# Altium schematic sheet [8]-GSM_MODEM_CONNECTORS.SchDoc([8]-GSM_MODEM_CONNECTORS)
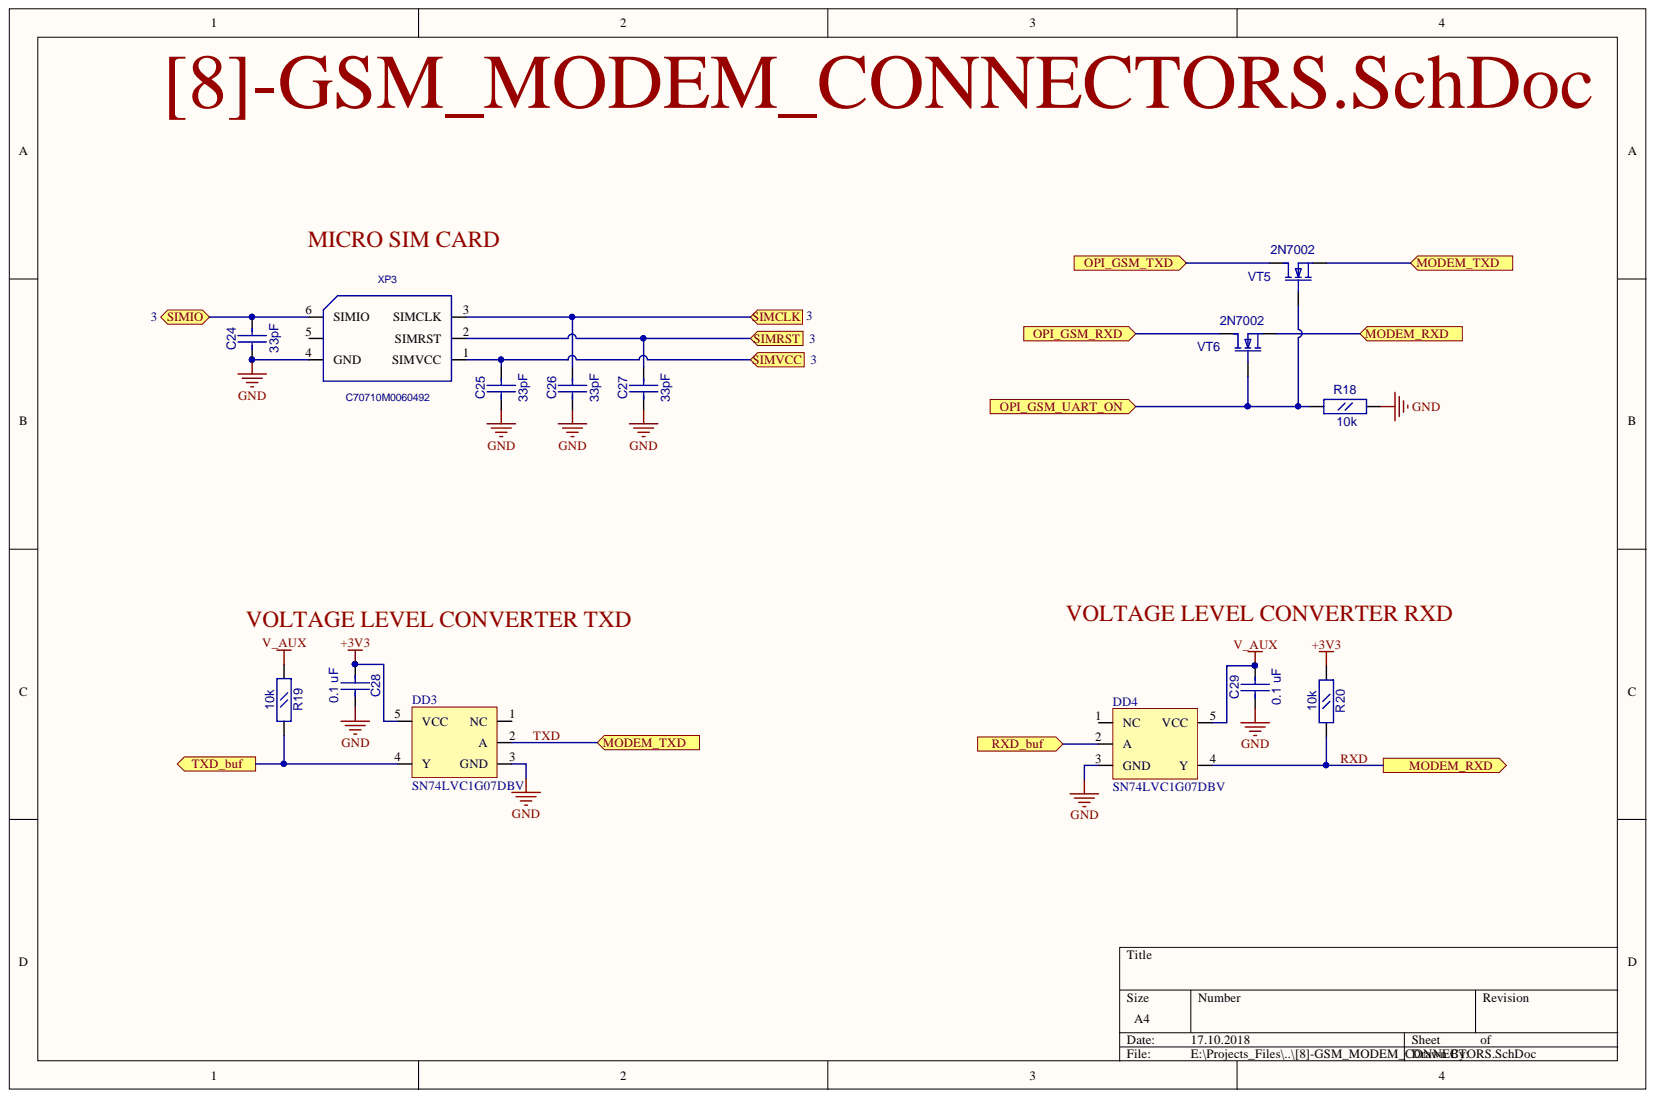
[diagram p0: [8]-GSM_MODEM_CONNECTORS.SchDoc]

## Parts
C24  33pF  [CC0603]
C25  33pF  [CC0603]
C26  33pF  [CC0603]
C27  33pF  [CC0603]
C28  0.1 uF  [CC0603]
C29  0.1 uF  [CC0603]
DD3  SN74LVC1G07DBV  [SOT23-5]
DD4  SN74LVC1G07DBV  [SOT23-5]
R18  10k  [RC0603]
R19  10k  [RC0603]
R20  10k  [RC0603]
VT5  2N7002  [SOT-23(123)]
VT6  2N7002  [SOT-23(123)]
XP3  C70710M0060492  [SCVW06A25020]

## Ports
MODEM_RXD, MODEM_TXD, OPI_GSM_RXD, OPI_GSM_TXD, OPI_GSM_UART_ON, RXD_buf, SIMCLK, SIMIO, SIMRST, SIMVCC, TXD_buf

## Nets
+3V3: C28.2 DD3.5(VCC) R20.1
GND: C24.1 C25.1 C26.1 C27.1 C28.1 C29.1 DD3.3(GND) DD4.3(GND) R18.2 XP3.4(GND)
V_AUX: C29.2 DD4.5(VCC) R19.1
OPI_GSM_RXD: VT6.3 ; port OPI_GSM_RXD
OPI_GSM_TXD: VT5.3 ; port OPI_GSM_TXD
OPI_GSM_UART_ON: R18.1 VT5.1 VT6.1 ; port OPI_GSM_UART_ON
RXD: DD4.4(Y) R20.2 VT6.2 ; port MODEM_RXD
RXD_buf: DD4.2(A) ; port RXD_buf
SIMCLK: C26.2 XP3.3(SIMCLK) ; port SIMCLK
SIMIO: C24.2 XP3.6(SIMIO) ; port SIMIO
SIMRST: C27.2 XP3.2(SIMRST) ; port SIMRST
SIMVCC: C25.2 XP3.1(SIMVCC) ; port SIMVCC
TXD: DD3.2(A) VT5.2 ; port MODEM_TXD
TXD_buf: DD3.4(Y) R19.2 ; port TXD_buf

Not connected: DD3 1(NC); DD4 1(NC); XP3 5

## Library data of the parts
C24-C29 = CC0603: Description: CC0603; Component Kind: Standard; Library Name: gprs_modem_2.SchLib
  C24-C27: Value: 33pF
  C28 C29: Value: 0.1 uF
DD3 DD4 = SN74LVC1G07DBV: Open Drain Single Bus Bufer; Component Kind: Standard; Library Name: gprs_modem_2.SchLib
R18-R20 = RC0603: Value: 10k; Description: RC0603; Component Kind: Standard
  R18: Library Name: fizik_lib.SchLib
  R19 R20: Library Name: gprs_modem_2.SchLib
VT5 VT6 = 2N7002: N-Channel Enhancement Mode Vertical DMOS FET; Simulation: 2N7002; OriginalName: 2N7002; Component Kind: Standard; Library Name: fizik_lib.SchLib
XP3 = SCV-W06A25-020: Description: SCV-W06A25-020; Component Kind: Standard; Library Name: gprs_modem_2.SchLib
